# Altium schematic sheet Input_Section.SchDoc
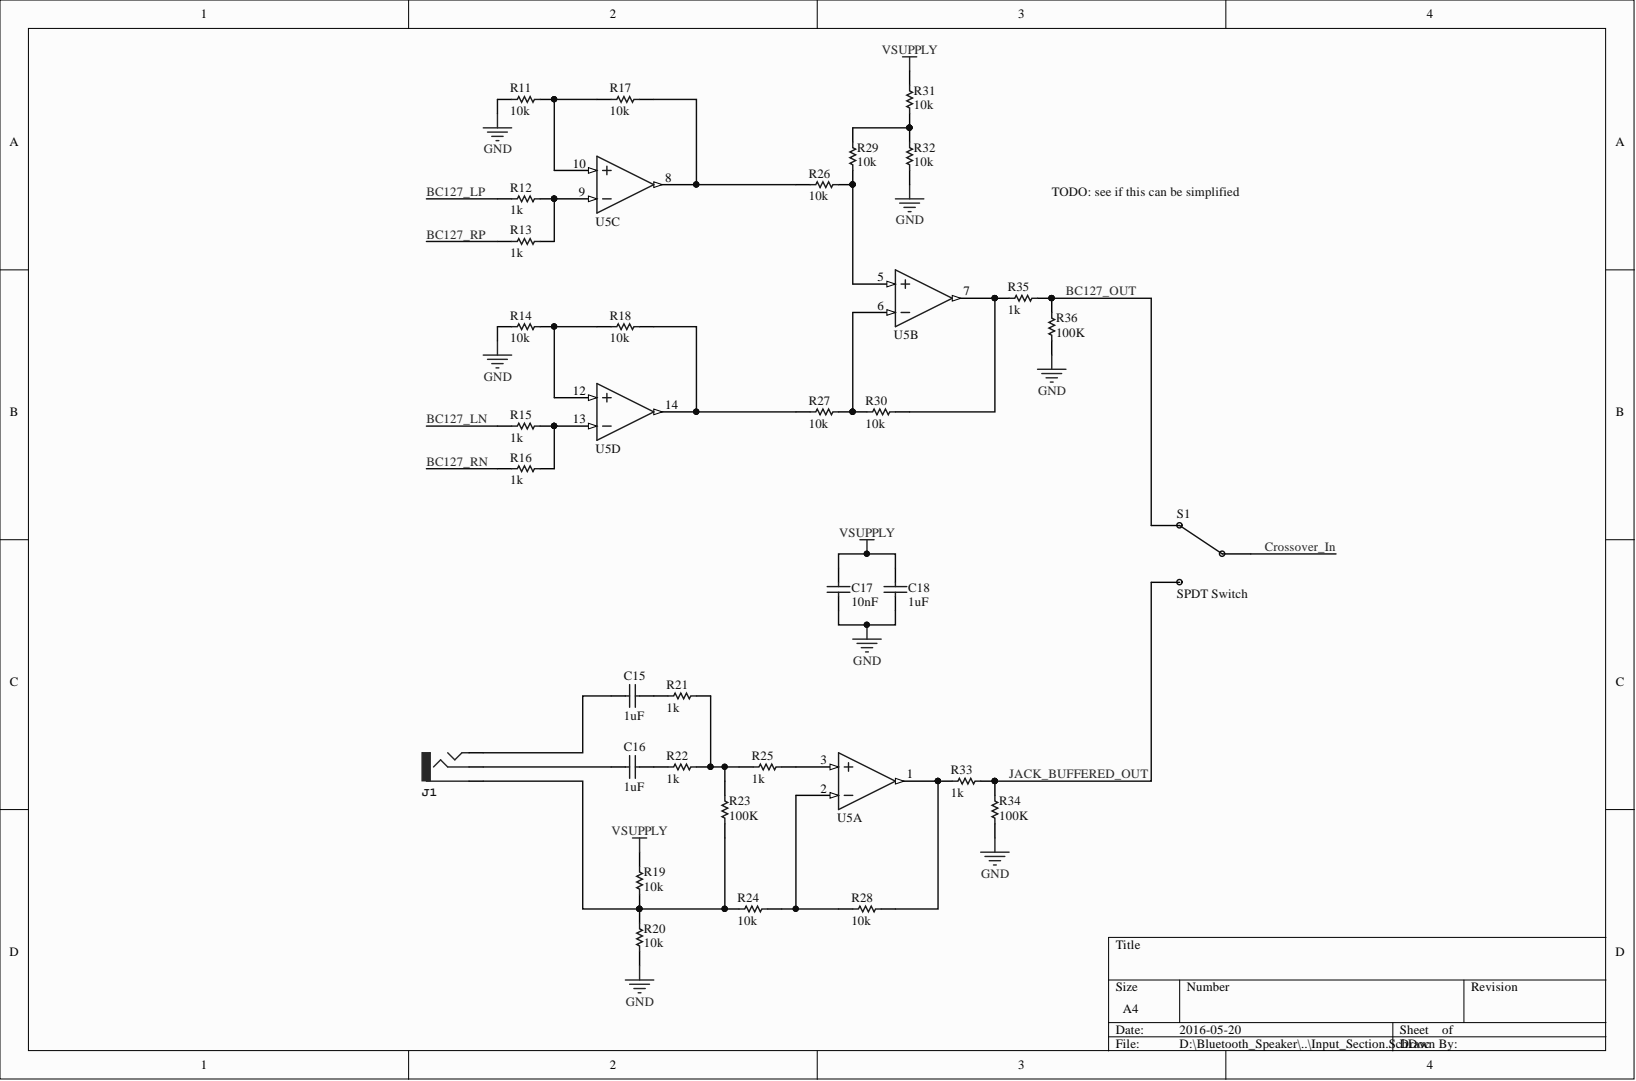
[diagram p0: Input_Section.SchDoc]

## Parts
C15  1uF  [CAP Radial 5mm]
C16  1uF  [CAP Radial 5mm]
C17  10nF  [CAPC1608X09N]
C18  1uF  [CAPC1608X09N]
J1  3.5mm Audio Jack  [70543-0002]  (value not drawn)
R11  10k  [0603]
R12  1k  [0603]
R13  1k  [0603]
R14  10k  [0603]
R15  1k  [0603]
R16  1k  [0603]
R17  10k  [0603]
R18  10k  [0603]
R19  10k  [0603]
R20  10k  [0603]
R21  1k  [0603]
R22  1k  [0603]
R23  100K  [0603]
R24  10k  [0603]
R25  1k  [0603]
R26  10k  [0603]
R27  10k  [0603]
R28  10k  [0603]
R29  10k  [0603]
R30  10k  [0603]
R31  10k  [0603]
R32  10k  [0603]
R33  1k  [0603]
R34  100K  [0603]
R35  1k  [0603]
R36  100K  [0603]
S1  SPDT Switch  [70543-0002]
U5  OPA4134UA  [SOIC127P600X175-14N]  (value not drawn)  (parts A,B,C,D)

## Nets
GND: C17.1 C18.2 R11.1 R14.1 R20.1 R32.1 R34.1 R36.1 U5.11
VSUPPLY: C17.2 C18.1 R19.2 R31.2 U5.4
BC127_LN: R15.1
BC127_LP: R12.1
BC127_OUT: R35.2 R36.2 S1.2
BC127_RN: R16.1
BC127_RP: R13.1
CROSSOVER_IN: S1.1
JACK_BUFFERED_OUT: R33.2 R34.2 S1.3
NetC15_1: C15.1 J1.3
NetC15_2: C15.2 R21.1
NetC16_1: C16.1 J1.2
NetC16_2: C16.2 R22.1
NetJ1_1: J1.1 R19.1 R20.2 R23.1 R24.1
NetR11_2: R11.2 R17.1 U5.10
NetR12_2: R12.2 R13.2 U5.9
NetR14_2: R14.2 R18.1 U5.12
NetR15_2: R15.2 R16.2 U5.13
NetR17_2: R17.2 R26.1 U5.8
NetR18_2: R18.2 R27.1 U5.14
NetR21_2: R21.2 R22.2 R23.2 R25.1
NetR24_2: R24.2 R28.1 U5.2
NetR25_2: R25.2 U5.3
NetR26_2: R26.2 R29.1 U5.5
NetR27_2: R27.2 R30.2 U5.6
NetR28_2: R28.2 R33.1 U5.1
NetR29_2: R29.2 R31.1 R32.2
NetR30_1: R30.1 R35.1 U5.7

## Library data of the parts
C15 C16 = CAP 1uF Radial 5mm: Audio coupling cap; Manufacturer: EPCOS (TDK); Manufacturer P/N: B32529C105J; Voltage: 63V; Component Kind: Standard; Digikey Part Number: 495-1119-ND; Value: 1uF; Library Name: Speaker Library.SchLib
C17 = CAP CER 10nF 0603: Bypass caps; Manufacturer: Murata; Component Kind: Standard; Digikey Part Number: 490-9666-1-ND; Value: 10nF; Library Name: Speaker Library.SchLib
C18 = CAP CER 1uF 0603: Voltage: 35V; Component Kind: Standard; Digikey Part Number: 490-10487-1-ND; Value: 1uF; Library Name: Speaker Library.SchLib
R11 R14 R17-R20 R24 R26-R32 = RES 10K 0603: Manufacturer: Yageo; Manufacturer Part Number: RC0603FR-0710KL; Power: 0.1W; Tolerance: 1%; Component Kind: Standard; Digikey Part Number: 311-10.0KHRCT-ND; Temperature: 155dC; Type: RES; Value: 10k; Library Name: Speaker Library.SchLib
R12 R13 R15 R16 R21 R22 R25 R33 R35 = RES 1K 0603: Manufacturer: Panasonic; Manufacturer Part Number: ERJ-PA3F1001V; Power: 0.25W; Tolerance: 1%; Component Kind: Standard; Digikey Part Number: P1.0KBYCT-ND; Temperature: 155dC; Type: RES; Value: 1k; Library Name: Speaker Library.SchLib
R23 R34 R36 = RES 100K 0603: Power: 0.1W; Tolerance: 1%; Component Kind: Standard; Value: 100K; Library Name: Speaker Library.SchLib
U5 = OPA4134UA: Quad OPAx134 Operational Amplifier; Component Kind: Standard; Library Name: Speaker Library.SchLib
J1 = 3.5mm Audio Jack: Component Kind: Standard; Library Name: Speaker Library.SchLib
S1 = SPDT Switch: Component Kind: Standard; Library Name: Speaker Library.SchLib
